# Altium schematic sheet Schematic.SchDoc
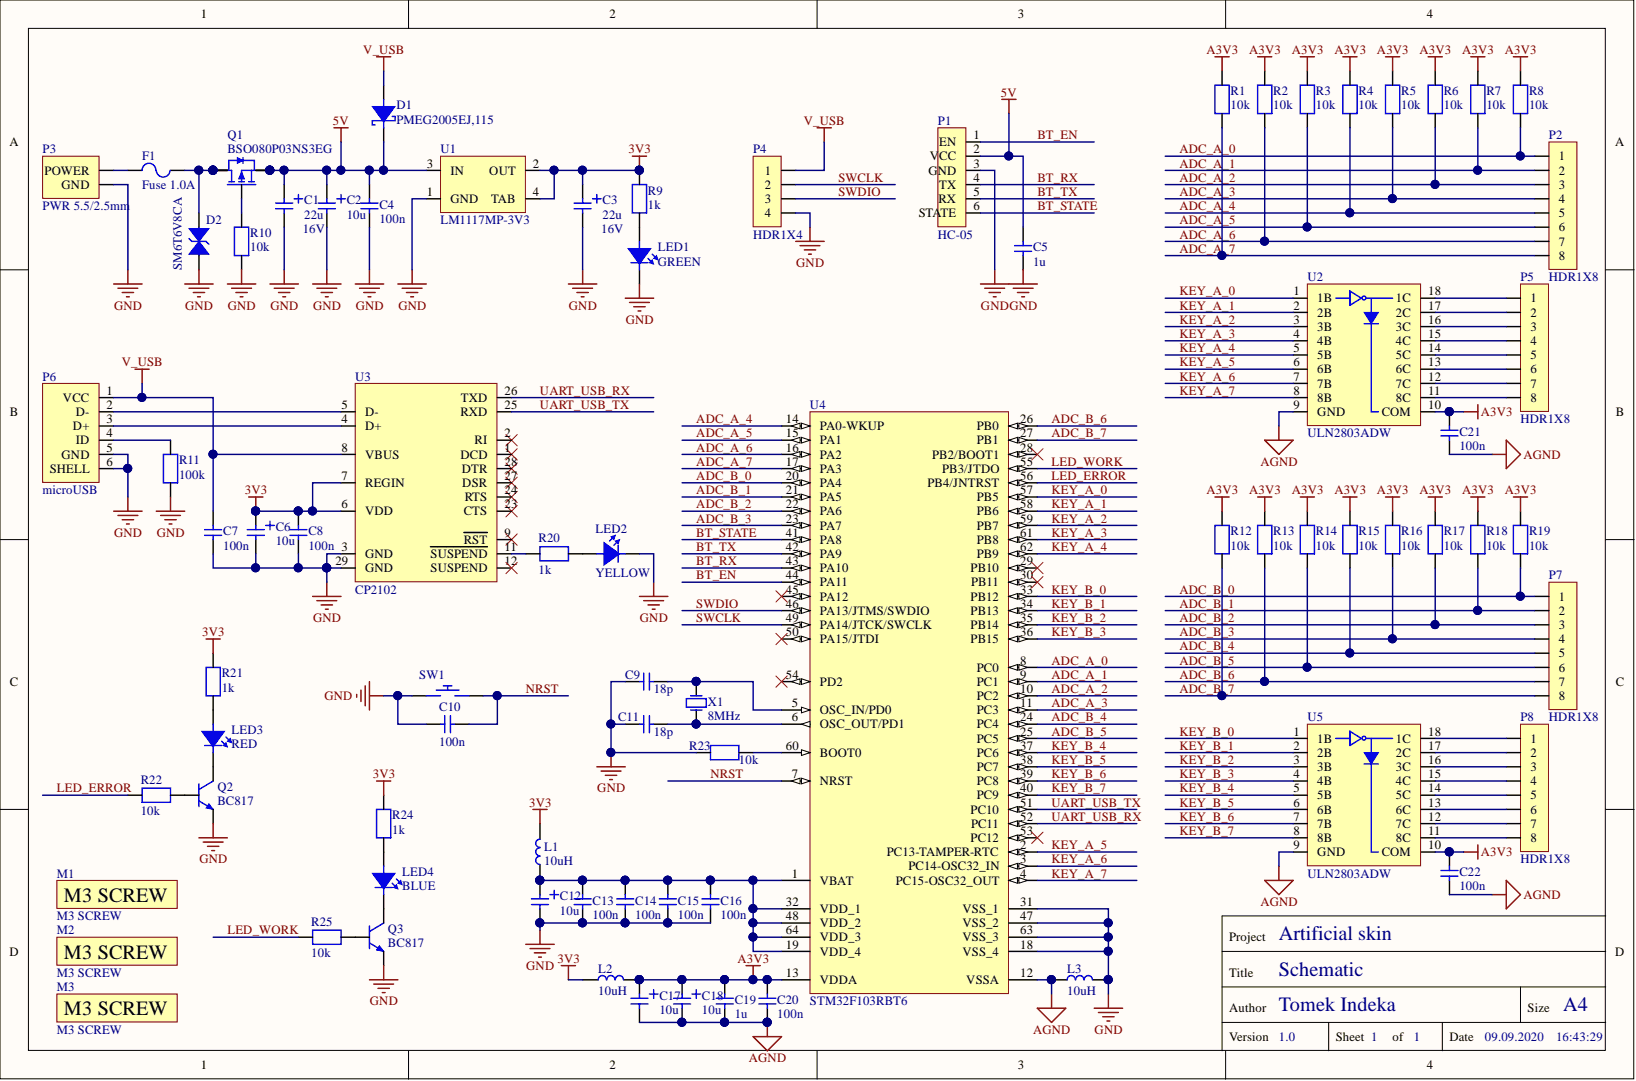
[diagram p0: Schematic.SchDoc]

## Parts
C1  22u  Voltage Rating: 16V  [C_POL_SMD_4x5]
C2  10u  [C_POL_0805]
C3  22u  Voltage Rating: 16V  [C_POL_SMD_4x5]
C4  100n  [C_0603]
C5  1u  [C_0603]
C6  10u  [C_POL_0805]
C7  100n  [C_0603]
C8  100n  [C_0603]
C9  18p  [C_0603]
C10  100n  [C_0603]
C11  18p  [C_0603]
C12  10u  [C_POL_0805]
C13  100n  [C_0603]
C14  100n  [C_0603]
C15  100n  [C_0603]
C16  100n  [C_0603]
C17  10u  [C_POL_SMD_4x5]
C18  10u  [C_POL_0805]
C19  1u  [C_0603]
C20  100n  [C_0603]
C21  100n  [C_0603]
C22  100n  [C_0603]
D1  PMEG2005EJ,115  [D_SOD-323]
D2  SM6T6V8CA  [D_SMB]
F1  Fuse 1.0A  [F_PTC_1206]
L1  10uH  [L_0603]
L2  10uH  [L_0603]
L3  10uH  [L_0603]
LED1  GREEN  [D_LED_0603]
LED2  YELLOW  [D_LED_0603]
LED3  RED  [D_LED_0603]
LED4  BLUE  [D_LED_0603]
M1  M3 SCREW  [M_M3_SCREW]
M2  M3 SCREW  [M_M3_SCREW]
M3  M3 SCREW  [M_M3_SCREW]
P1  HC-05  [P_HDR1X6]
P2  HDR1X8  [P_JST_2.0_1x8]
P3  PWR 5.5/2.5mm  [P_PWR_5.5/2.5]
P4  HDR1X4  [P_MOLEX_2.5_1x4]
P5  HDR1X8  [P_JST_2.0_1x8]
P6  microUSB  [P_USB_micro_v3]
P7  HDR1X8  [P_JST_2.0_1x8]
P8  HDR1X8  [P_JST_2.0_1x8]
Q1  BSO080P03NS3EG  [U_SO-8]
Q2  BC817  [U_SOT-23]
Q3  BC817  [U_SOT-23]
R1  10k  [R_0603]
R2  10k  [R_0603]
R3  10k  [R_0603]
R4  10k  [R_0603]
R5  10k  [R_0603]
R6  10k  [R_0603]
R7  10k  [R_0603]
R8  10k  [R_0603]
R9  1k  [R_0603]
R10  10k  [R_0603]
R11  100k  [R_0603]
R12  10k  [R_0603]
R13  10k  [R_0603]
R14  10k  [R_0603]
R15  10k  [R_0603]
R16  10k  [R_0603]
R17  10k  [R_0603]
R18  10k  [R_0603]
R19  10k  [R_0603]
R20  1k  [R_0603]
R21  1k  [R_0603]
R22  10k  [R_0603]
R23  10k  [R_0603]
R24  1k  [R_0603]
R25  10k  [R_0603]
SW1  Micro Switch  [SW_Tact_34N-F]  (value not drawn)
U1  LM1117MP-3V3  [U_SOT-223]
U2  ULN2803ADW  [U_SOIC_20_Wide]
U3  CP2102  [U_QFN_28_5mm]
U4  STM32F103RBT6  [U_LQFP-64]
U5  ULN2803ADW  [U_SOIC_20_Wide]
X1  8MHz  [XTAL_HC49-4H-THT]

## Nets
3V3: C3.1 C6.1 C8.1 L1.2 L2.1 R9.2 R21.2 R24.2 U1.2(OUT) U1.4(TAB) U3.6(VDD) U3.7(REGIN)
5V: C1.1 C2.1 C4.1 C5.1 D1.2 P1.2 Q1.1 Q1.2 Q1.3 U1.3(IN)
A3V3: C17.1 C18.1 C19.1 C20.1 C21.1 C22.1 L2.2 R1.2 R2.2 R3.2 R4.2 R5.2 R6.2 R7.2 R8.2 R12.2 R13.2 R14.2 R15.2 R16.2 R17.2 R18.2 R19.2 U2.10(COM) U4.13(VDDA) U5.10(COM)
AGND: C17.2 C18.2 C19.2 C20.2 C21.2 C22.2 L3.1 U2.9(GND) U4.12(VSSA) U5.9(GND)
GND: C1.2 C2.2 C3.2 C4.2 C5.2 C6.2 C7.2 C8.2 C9.1 C10.2 C11.1 C12.2 C13.2 C14.2 C15.2 C16.2 D2.1 L3.2 LED1.2 LED2.2 P1.3 P3.2 P4.4 P6.5(GND) P6.6(SHELL) Q2.E Q3.E R10.1 R11.1 R23.1 SW1.2 U1.1(GND) U3.3(GND) U3.29(GND) U4.18(VSS_4) U4.31(VSS_1) U4.47(VSS_2) U4.63(VSS_3)
V_USB: C7.1 D1.1 P4.1 P6.1(VCC) U3.8(VBUS)
ADC_A_0: P2.1 R8.1 U4.8(PC0)
ADC_A_1: P2.2 R7.1 U4.9(PC1)
ADC_A_2: P2.3 R6.1 U4.10(PC2)
ADC_A_3: P2.4 R5.1 U4.11(PC3)
ADC_A_4: P2.5 R4.1 U4.14(PA0-WKUP)
ADC_A_5: P2.6 R3.1 U4.15(PA1)
ADC_A_6: P2.7 R2.1 U4.16(PA2)
ADC_A_7: P2.8 R1.1 U4.17(PA3)
ADC_B_0: P7.1 R19.1 U4.20(PA4)
ADC_B_1: P7.2 R18.1 U4.21(PA5)
ADC_B_2: P7.3 R17.1 U4.22(PA6)
ADC_B_3: P7.4 R16.1 U4.23(PA7)
ADC_B_4: P7.5 R15.1 U4.24(PC4)
ADC_B_5: P7.6 R14.1 U4.25(PC5)
ADC_B_6: P7.7 R13.1 U4.26(PB0)
ADC_B_7: P7.8 R12.1 U4.27(PB1)
BT_EN: P1.1(EN) U4.44(PA11)
BT_RX: P1.4(TX) U4.43(PA10)
BT_STATE: P1.6 U4.41(PA8)
BT_TX: P1.5(RX) U4.42(PA9)
KEY_A_0: U2.1(1B) U4.57(PB5)
KEY_A_1: U2.2(2B) U4.58(PB6)
KEY_A_2: U2.3(3B) U4.59(PB7)
KEY_A_3: U2.4(4B) U4.61(PB8)
KEY_A_4: U2.5(5B) U4.62(PB9)
KEY_A_5: U2.6(6B) U4.2(PC13-TAMPER-RTC)
KEY_A_6: U2.7(7B) U4.3(PC14-OSC32_IN)
KEY_A_7: U2.8(8B) U4.4(PC15-OSC32_OUT)
KEY_B_0: U4.33(PB12) U5.1(1B)
KEY_B_1: U4.34(PB13) U5.2(2B)
KEY_B_2: U4.35(PB14) U5.3(3B)
KEY_B_3: U4.36(PB15) U5.4(4B)
KEY_B_4: U4.37(PC6) U5.5(5B)
KEY_B_5: U4.38(PC7) U5.6(6B)
KEY_B_6: U4.39(PC8) U5.7(7B)
KEY_B_7: U4.40(PC9) U5.8(8B)
LED_ERROR: R22.1 U4.56(PB4/JNTRST)
LED_WORK: R25.1 U4.55(PB3/JTDO)
NRST: C10.1 SW1.1 U4.7(NRST)
SWCLK: P4.2 U4.49(PA14/JTCK/SWCLK)
SWDIO: P4.3 U4.46(PA13/JTMS/SWDIO)
UART_USB_RX: U3.26(TXD) U4.52(PC11)
UART_USB_TX: U3.25(RXD) U4.51(PC10)
NetC9_2: C9.2 U4.5(OSC_IN/PD0) X1.2
NetC11_2: C11.2 U4.6(OSC_OUT/PD1) X1.1
NetC12_1: C12.1 C13.1 C14.1 C15.1 C16.1 L1.1 U4.1(VBAT) U4.19(VDD_4) U4.32(VDD_1) U4.48(VDD_2) U4.64(VDD_3)
NetD2_2: D2.2 F1.1 Q1.5 Q1.6 Q1.7 Q1.8
NetF1_2: F1.2 P3.1
NetLED1_1: LED1.1 R9.1
NetLED2_1: LED2.1 R20.1
NetLED3_1: LED3.1 R21.1
NetLED3_2: LED3.2 Q2.C
NetLED4_1: LED4.1 R24.1
NetLED4_2: LED4.2 Q3.C
NetP5_1: P5.1 U2.18(1C)
NetP5_2: P5.2 U2.17(2C)
NetP5_3: P5.3 U2.16(3C)
NetP5_4: P5.4 U2.15(4C)
NetP5_5: P5.5 U2.14(5C)
NetP5_6: P5.6 U2.13(6C)
NetP5_7: P5.7 U2.12(7C)
NetP5_8: P5.8 U2.11(8C)
NetP6_2: P6.2(D-) U3.5(D-)
NetP6_3: P6.3(D+) U3.4(D+)
NetP6_4: P6.4(ID) R11.2
NetP8_1: P8.1 U5.18(1C)
NetP8_2: P8.2 U5.17(2C)
NetP8_3: P8.3 U5.16(3C)
NetP8_4: P8.4 U5.15(4C)
NetP8_5: P8.5 U5.14(5C)
NetP8_6: P8.6 U5.13(6C)
NetP8_7: P8.7 U5.12(7C)
NetP8_8: P8.8 U5.11(8C)
NetQ1_4: Q1.4 R10.2
NetQ2_B: Q2.B R22.2
NetQ3_B: Q3.B R25.2
NetR20_2: R20.2 U3.11(SUSPEND)
NetR23_2: R23.2 U4.60(BOOT0)

Not connected: U3 1(DCD) 2(RI) 9(RST) 12(SUSPEND) 23(CTS) 24(RTS) 27(DSR) 28(DTR); U4 28(PB2/BOOT1) 29(PB10) 30(PB11) 45(PA12) 50(PA15/JTDI) 53(PC12) 54(PD2)

## Library data of the parts
C1-C3 C6 C12 C18 = C_POL_CAP: Polar capacitor; Assembly: THT; Component Kind: Standard; Pins: 2; Library Name: KNR_Rover_Lib.IntLib
  C1 C3: Category: Polar Capacitors; PartAssemblyNote: 22u
  C2 C12 C18: Category: Polar Capacitors; PartAssemblyNote: 10u
  C6: Category: Tantalum Capacitors; PartAssemblyNote: 10u
C4 C5 C7 C8 C13-C16 C19-C22 = C_CAP: Capacitor; Assembly: SMT; Voltage Rating: 25V; Category: Ceramic Capacitors; Component Kind: Standard; Pins: 2; Library Name: KNR_Rover_Lib.IntLib
  C4 C7 C8 C13-C16 C20-C22: PartAssemblyNote: 100n
  C5 C19: PartAssemblyNote: 1u
C9-C11 = C_CAP: Capacitor; Assembly: SMT; Category: Ceramic Capacitors; Component Kind: Standard; Pins: 2; Library Name: KNR_Rover_Lib.IntLib
  C9 C11: PartAssemblyNote: 18p
  C10: PartAssemblyNote: 100n
C17 = C_POL_CAP: Polar capacitor; Assembly: THT; Voltage Rating: 16V; Category: Polar Capacitors; Component Kind: Standard; PartAssemblyNote: 10u; Pins: 2; Library Name: KNR_Rover_Lib.IntLib
D1 = D_SCHOTTKY_PMEG2005EJ: dioda Schottky'ego; Manufacturer: NXP Semiconductors; Assembly: SMT; Category: Schottky Diodes; Component Kind: Standard; PartAssemblyNote: PMEG2005EJ,115; Pins: 2; Library Name: KNR_Rover_Lib.IntLib
D2 = D_TRANSIL_SM6T6V8CA: STMicroelectronics, transil, 6.8V, SMB, 600W; Assembly: SMT; Category: TVS Diodes; Component Kind: Standard; Manufacturer: STMicroelectronics; Manufacturer Part Number: SM6T6V8CA; PartAssemblyNote: SM6T6V8CA; Pins: 2; Library Name: KNR_Rover_Lib.IntLib
F1 = F_PTC_0.5A: ERBRG1R00V; Manufacturer: Panasonic; Assembly: SMT; Category: Fuses; Component Kind: Standard; PartAssemblyNote: ERBRG1R00V; Pins: 2; Library Name: KNR_Rover_Lib.IntLib
L1-L3 = L_Coil: Inductor; Assembly: SMT; Category: Inductors; Component Kind: Standard; PartAssemblyNote: 10uH; Pins: 2; Library Name: KNR_Rover_Lib.IntLib
LED1-LED4 = D_LED: LED; Assembly: SMT; Category: LEDs; Component Kind: Standard; Pins: 2; Library Name: KNR_Rover_Lib.IntLib
  LED1: PartAssemblyNote: GREEN
  LED2: PartAssemblyNote: YELLOW
  LED3: PartAssemblyNote: RED
  LED4: PartAssemblyNote: BLUE
M1-M3 = M_M3_SCREW: Śruba M3 do mocowania PCB; Assembly: MMT; Category: Screws; Component Kind: Standard; PartAssemblyNote: M3 SCREW; Pins: 0; Library Name: KNR_Rover_Lib.IntLib
P1 = P_HDR1X6: Header, 6-Pin; Assembly: THT; Category: Connectors; Component Kind: Standard; PartAssemblyNote: HC-05; Pins: 6; Library Name: KNR_Rover_Lib.IntLib
P2 P5 P7 P8 = P_HDR1X8: Header, 8-Pin; PartAssemblyNote: JST 2.0mm 8pin; Assembly: THT; Category: Connectors; Component Kind: Standard; Pins: 8; Library Name: KNR_Rover_Lib.IntLib
P3 = P_PWR: Component Kind: Standard; PartAssemblyNote: PWR 5.5/2.5mm; Library Name: Library_TI_wlasna.IntLib
P4 = P_HDR1X4: Header, 4-Pin; PartAssemblyNote: Molex 2.5mm 4 pin; Assembly: THT; Category: Connectors; Component Kind: Standard; Pins: 4; Library Name: KNR_Rover_Lib.IntLib
P6 = P_USB_micro: Component Kind: Standard; PartAssemblyNote: microUSB; Library Name: Library_TI_wlasna.IntLib
Q1 = Q_BSO080P03NS3EG: tranzystor MOSFET kanał P 30V 12A; Manufacturer: Infineon; Assembly: SMT; Category: MOSFETs; Component Kind: Standard; PartAssemblyNote: BSO080P03NS3EG; Pins: 8; Library Name: KNR_Rover_Lib.IntLib
Q2 Q3 = Q_BC817: NPN transistor; Assembly: SMT; Category: BJTs; Component Kind: Standard; PartAssemblyNote: BC817; Pins: 3; Library Name: KNR_Rover_Lib.IntLib
R1-R25 = R_RES: Resistor; Assembly: SMT; Category: Resistors; Component Kind: Standard; Pins: 2; Library Name: KNR_Rover_Lib.IntLib
  R1-R8 R10 R12-R19 R22 R23 R25: PartAssemblyNote: 10k
  R9 R20 R21 R24: PartAssemblyNote: 1k
  R11: PartAssemblyNote: 100k
SW1 = SW_MicroSwitch: Micro Switch, pushbutton, monostable; PartAssemblyNote: TACT-34N-F; Assembly: SMT; Category: Pushbutton Switches; Component Kind: Standard; Pins: 2; Library Name: KNR_Rover_Lib.IntLib
U1 = U_LM1117MP-3V3: 800mA Low-Dropout Linear Regulator; Manufacturer: Texas Instruments; Assembly: SMT; Category: Voltage Regulators - Linear; Component Kind: Standard; PartAssemblyNote: LM1117MP-3V3; Pins: 4; Library Name: KNR_Rover_Lib.IntLib
U2 U5 = U_ULN2803ADW: Darlington Transistor Arrays; Aseembly: SMT; Component Kind: Standard; PartAssemblyNote: ULN2803ADW; Library Name: Library_TI_wlasna.IntLib
U3 = U_CP2102: SINGLE-CHIP USB-TO-UART BRIDGE; Component Kind: Standard; PartAssemblyNote: CP2102; Library Name: Library_TI_wlasna.IntLib
U4 = U_STM32F103RBT6: STM32 ARM-based 32-bit; Manufacturer: STMicroelectronics; Assembly: SMT; Category: Microcontrollers; Component Kind: Standard; PartAssemblyNote: STM32F103RBT6; Pins: 64; Library Name: KNR_Rover_Lib.IntLib
X1 = XTAL: Oscillator; Assembly: THT; Category: Oscillators; Component Kind: Standard; PartAssemblyNote: 8MHz; Pins: 2; Library Name: KNR_Rover_Lib.IntLib
